# Altium schematic sheet RT_SUPER_B.SchDoc(RT_SUPER_B) (continued)

## Library data of the parts
BTN1 RESET1 = B3U-1100P: SWITCH TACTILE SPST-NO 0.05A 12V; Manufacturer: Omron Electronics Inc-EMC Div; Actuator Height off PCB, from Pins: 1.60mm; Actuator Orientation: Top Actuated; Actuator Type: Standard; CategorySort: 700; Contact Rating @ Voltage: 0.05A @ 12VDC; Illumination: Non-Illuminated; Ingress Protection: Dust Proof; Mechanical Life: 200,000 Cycles; Operating Force: 153gf; Outline: 3.00mm x 2.50mm; Switch Function: Off-Mom; Switch Travel: 0.15mm; Actuator Material: -; Category: Switches; Circuit: SPST-NO; Component Kind: Standard; ComponentLink1Description: http://www.components.omron.com/components/web/PDFLIB.nsf/0/2DD3893C7DD33E9E862572900079E9D6/$file/B3U_1110.pdf; ComponentLink1URL: http://www.components.omron.com/components/web/PDFLIB.nsf/0/2DD3893C7DD33E9E862572900079E9D6/$file/B3U_1110.pdf; Features: Grounding Pin; Illumination Type, Color: -; Illumination Voltage (Nominal): -; Library Name: Switches-N.SchLib; Manufacturer Part Number: B3U-1100P; Mounting Type: Surface Mount; Operating Temperature: -25°C ~ 70°C; Packaging: Cut Tape (CT); Pricing 1: 1=1.25, 10=1.201, 25=1.1332, 50=0.997, 100=0.9064, 250=0.86108, 500=0.83842, 1000=0.65714 (USD); RoHS: RoHS Compliant; Stock 1: 45170; Supplier 1: Digi-Key; Supplier Part Number 1: SW1021CT-ND; Termination Style: SMD (SMT) Tab; Value: B3U-1100P
C1 C5 C18 = GCM188R70J225KE22D: CAP CER 2.2UF 6.3V X7R 0603; Manufacturer: Murata Electronics; Database Table Name: C0603$; Substitution Notes: 2.2µF , 6.3V , ±10% , X7R , -55°C ~ 125°C , AEC-Q200 , 0603; Tolerance: ±10%; Category: Capacitors; Component Kind: Standard; ComponentLink1Description: Datasheet (Internal); ComponentLink1URL: https://search.murata.co.jp/Ceramy/image/img/A01X/G101/ENG/GCM188R70J225KE22-01.pdf; ComponentLink2Description: Datasheet (External); ComponentLink2URL: https://search.murata.co.jp/Ceramy/image/img/A01X/G101/ENG/GCM188R70J225KE22-01.pdf; Library Name: Capacitors - Murata - AEC-Q200.DbLib; Manufacturer Part Number: GCM188R70J225KE22D; Operating Temperature: -55°C ~ 125°C; Package: 0603; Ratings: AEC-Q200; Supplier 1: Digikey; Supplier Part Number 1: 490-5316-1-ND; Temperature Coefficient: X7R; Thickness (Max): 0.90MM; Value: 2.2µF; Voltage - Rated: 6.3V
C2 = GCJ188C70J475KE02D: CAP CER 4.7UF 6.3V X7S 0603; Manufacturer: Murata Electronics; Database Table Name: C0603$; Substitution Notes: 4.7µF , 6.3V , ±10% , X7S , -55°C ~ 125°C , AEC-Q200 , 0603; Tolerance: ±10%; Category: Capacitors; Component Kind: Standard; ComponentLink1Description: Datasheet (Internal); ComponentLink1URL: https://search.murata.co.jp/Ceramy/image/img/A01X/G101/ENG/GCJ188C70J475KE02-01.pdf; ComponentLink2Description: Datasheet (External); ComponentLink2URL: https://search.murata.co.jp/Ceramy/image/img/A01X/G101/ENG/GCJ188C70J475KE02-01.pdf; Library Name: Capacitors - Murata - AEC-Q200.DbLib; Manufacturer Part Number: GCJ188C70J475KE02D; Operating Temperature: -55°C ~ 125°C; Package: 0603; Ratings: AEC-Q200; Supplier 1: Digikey; Supplier Part Number 1: 490-16480-1-ND; Temperature Coefficient: X7S; Thickness (Max): 1.00MM; Value: 4.7µF; Voltage - Rated: 6.3V
C3 = GRT155R61E474KE01D: CAP CER 0.47UF 25V X5R 0402; Manufacturer: Murata Electronics; Database Table Name: C0402$; Substitution Notes: 0.47µF , 25V , ±10% , X5R , -55°C ~ 85°C , AEC-Q200 , 0402; Tolerance: ±10%; Category: Capacitors; Component Kind: Standard; ComponentLink1Description: Datasheet (Internal); ComponentLink1URL: https://search.murata.co.jp/Ceramy/image/img/A01X/partnumbering_e_02.pdf; ComponentLink2Description: Datasheet (External); ComponentLink2URL: https://search.murata.co.jp/Ceramy/image/img/A01X/partnumbering_e_02.pdf; Library Name: Capacitors - Murata - AEC-Q200.DbLib; Manufacturer Part Number: GRT155R61E474KE01D; Operating Temperature: -55°C ~ 85°C; Package: 0402; Ratings: AEC-Q200; Supplier 1: Digikey; Supplier Part Number 1: 490-12269-1-ND; Temperature Coefficient: X5R; Thickness (Max): 0.55MM; Value: 0.47µF; Voltage - Rated: 25V
C4 C8 C10 = GCM155C71A105KE38D: CAP CER 1UF 10V X7S 0402; Manufacturer: Murata Electronics; Database Table Name: C0402$; Substitution Notes: 1µF , 10V , ±10% , X7S , -55°C ~ 125°C , AEC-Q200 , 0402; Tolerance: ±10%; Category: Capacitors; Component Kind: Standard; ComponentLink1Description: Datasheet (Internal); ComponentLink1URL: https://www.murata.com/~/media/webrenewal/support/library/catalog/products/capacitor/mlcc/c03e.ashx?la=en-us; ComponentLink2Description: Datasheet (External); ComponentLink2URL: https://www.murata.com/~/media/webrenewal/support/library/catalog/products/capacitor/mlcc/c03e.ashx?la=en-us; Library Name: Capacitors - Murata - AEC-Q200.DbLib; Manufacturer Part Number: GCM155C71A105KE38D; Operating Temperature: -55°C ~ 125°C; Package: 0402; Ratings: AEC-Q200; Supplier 1: Digikey; Supplier Part Number 1: 490-13409-1-ND; Temperature Coefficient: X7S; Thickness (Max): 0.55MM; Value: 1µF; Voltage - Rated: 10V
C6 C12-C17 C20-C41 = (no symbol name): Cap Ceramic 0.1uF 6.3VDC X5R 10% SMD 0201 Paper T/R; Manufacturer: Yageo; Manufacturer Part Number: CC0201KRX5R5BB104; Capacitance: 100nF; Voltage Rating: 6.3V; Case Code (Imperial): 0201; Case Code (Metric): 0603; Case/Package: 0201; Category: Ceramic Capacitors; Component Kind: Standard; ComponentLink1Description: https://datasheet.ciiva.com/176/mlccorderingcode-176765.pdf?src-supplier=Digi-Key; ComponentLink1URL: https://datasheet.ciiva.com/176/mlccorderingcode-176765.pdf?src-supplier=Digi-Key; ComponentLink2Description: https://datasheet.ciiva.com/176/mlccorderingcode-176766.pdf?src-supplier=Digi-Key; ComponentLink2URL: https://datasheet.ciiva.com/176/mlccorderingcode-176766.pdf?src-supplier=Digi-Key; ComponentLink3Description: https://datasheet.ciiva.com/176/mlccorderingcode-176767.pdf?src-supplier=Digi-Key; ComponentLink3URL: https://datasheet.ciiva.com/176/mlccorderingcode-176767.pdf?src-supplier=Digi-Key; ComponentLink4Description: https://datasheet.ciiva.com/28450/1359354-28450200.pdf?src-supplier=Element14; ComponentLink4URL: https://datasheet.ciiva.com/28450/1359354-28450200.pdf?src-supplier=Element14; ComponentLink5Description: https://datasheet.ciiva.com/7656/1525783-7656597.pdf?src-supplier=Element14; ComponentLink5URL: https://datasheet.ciiva.com/7656/1525783-7656597.pdf?src-supplier=Element14; Depth: 0.3mm; Dielectric: X5R; Halogen Free: Halogen Free; Height: 0.3mm; Lead Free: Lead Free; Length: 0.6mm; Library Name: eCapacitors.SchLib; Max Operating Temperature: 85°C; Min Operating Temperature: -55°C; Mount: Surface Mount; Number of Pins: 2; Package Quantity: 1; Packaging: Tape and Reel; Pricing: 1=0.10000, 10=0.02400, 50=0.01340, 100=0.01090, 500=0.00776, 1000=0.00610, 2500=0.00555, 5000=0.00510 (USD); REACH SVHC: No SVHC; Resistor Type: Ceramic; RoHS: Yes; RoHS Compliant: Yes; Series: CC; Stock: 2999877; Supplier 1: Digi-Key; Supplier Part Number 1: 311-1408-1-ND; Termination: SMD/SMT; Thickness: 0.013inch; Tolerance: 10%; Value: 0.1uF 6v; Voltage Rating (DC): 6.3V; Width: 0.3mm
C7 C9 = GRT188R61A106ME13D: CAP CER 10UF 10V X5R 0603; Manufacturer: Murata Electronics; Database Table Name: C0603$; Substitution Notes: 10µF , 10V , ±20% , X5R , -55°C ~ 85°C , AEC-Q200 , 0603; Tolerance: ±20%; Category: Capacitors; Component Kind: Standard; ComponentLink1Description: Datasheet (Internal); ComponentLink1URL: https://search.murata.co.jp/Ceramy/image/img/A01X/G101/ENG/GRT188R61A106ME13-01.pdf; ComponentLink2Description: Datasheet (External); ComponentLink2URL: https://search.murata.co.jp/Ceramy/image/img/A01X/G101/ENG/GRT188R61A106ME13-01.pdf; Library Name: Capacitors - Murata - AEC-Q200.DbLib; Manufacturer Part Number: GRT188R61A106ME13D; Operating Temperature: -55°C ~ 85°C; Package: 0603; Ratings: AEC-Q200; Supplier 1: Digikey; Supplier Part Number 1: 490-12312-1-ND; Temperature Coefficient: X5R; Thickness (Max): 0.90MM; Value: 10µF; Voltage - Rated: 10V
C11 C19 = CGA2B2C0G1H180J050BA: CAP CER 18PF 50V C0G 0402; Manufacturer: TDK Corporation; Database Table Name: C0402$; Substitution Notes: 18pF , 50V , ±5% , C0G, NP0 , -55°C ~ 125°C , AEC-Q200 , 0402; Temperature Coefficient: C0G, NP0; Tolerance: ±5%; Category: Capacitors; Component Kind: Standard; ComponentLink1Description: Datasheet (Internal); ComponentLink1URL: datasheets\TDK\mlcc_automotive_general_en.pdf; ComponentLink2Description: Datasheet (External); ComponentLink2URL: https://product.tdk.com/info/en/catalog/datasheets/mlcc_automotive_general_en.pdf; Features: -; Library Name: Capacitors - TDK- AEC-Q200.DbLib; Manufacturer Part Number: CGA2B2C0G1H180J050BA; Operating Temperature: -55°C ~ 125°C; Package: 0402; Ratings: AEC-Q200; Supplier 1: Digikey; Supplier Part Number 1: 445-5587-1-ND; Thickness (Max): 0.55MM; Value: 18pF; Voltage - Rated: 50V
C42 = CGA4J3X7R1A475K125AB: CAP CER 4.7UF 10V X7R 0805; Manufacturer: TDK Corporation; Database Table Name: C0805$; Substitution Notes: 4.7µF , 10V , ±10% , X7R , -55°C ~ 125°C , AEC-Q200 , 0805; Tolerance: ±10%; Category: Capacitors; Component Kind: Standard; ComponentLink1Description: Datasheet (Internal); ComponentLink1URL: datasheets\TDK\mlcc_automotive_general_en.pdf; ComponentLink2Description: Datasheet (External); ComponentLink2URL: https://product.tdk.com/info/en/catalog/datasheets/mlcc_automotive_general_en.pdf; Features: -; Library Name: Capacitors - TDK- AEC-Q200.DbLib; Manufacturer Part Number: CGA4J3X7R1A475K125AB; Operating Temperature: -55°C ~ 125°C; Package: 0805; Ratings: AEC-Q200; Supplier 1: Digikey; Supplier Part Number 1: 445-12730-1-ND; Temperature Coefficient: X7R; Thickness (Max): 1.45MM; Value: 4.7µF; Voltage - Rated: 10V
C43 = CGA2B1X7R1C104K050BC: CAP CER 0.1UF 16V X7R 0402; Manufacturer: TDK Corporation; Database Table Name: C0402$; Substitution Notes: 0.1µF , 16V , ±10% , X7R , -55°C ~ 125°C , AEC-Q200 , 0402; Tolerance: ±10%; Category: Capacitors; Component Kind: Standard; ComponentLink1Description: Datasheet (Internal); ComponentLink1URL: datasheets\TDK\mlcc_automotive_general_en.pdf; ComponentLink2Description: Datasheet (External); ComponentLink2URL: https://product.tdk.com/info/en/catalog/datasheets/mlcc_automotive_general_en.pdf; Features: -; Library Name: Capacitors - TDK- AEC-Q200.DbLib; Manufacturer Part Number: CGA2B1X7R1C104K050BC; Operating Temperature: -55°C ~ 125°C; Package: 0402; Ratings: AEC-Q200; Supplier 1: Digikey; Supplier Part Number 1: 445-5613-1-ND; Temperature Coefficient: X7R; Thickness (Max): 0.55MM; Value: 0.1µF; Voltage - Rated: 16V
D1 D2 = BAT760-7: DIODE SCHOTTKY 30V 1A SOD323; Manufacturer: Diodes Inc; Capacitance @ Vr- F: 25pF @ 5V- 1MHz; CategorySort: 400; Current - Reverse Leakage @ Vr: 50µA @ 15V; Database Table Name: Schottky$; Diode Suffix: 1A_2C; Num Pins: 2; Speed: Fast Recovery =< 500ns- > 200mA (Io); Voltage - Forward (Vf) (Max) @ If: 550mV @ 1A; Category: Diodes; Component Kind: Standard; ComponentLink1Description: Internal Datasheet; ComponentLink1URL: http://www.diodes.com/datasheets/ds30498.pdf; ComponentLink2Description: External Datasheet; ComponentLink2URL: http://www.diodes.com/datasheets/ds30498.pdf; Current - Average Rectified (Io): 1A; Diode Type: Schottky; Library Name: Diodes.DbLib; Manufacturer Part Number: BAT760-7; Mounting Type: Surface Mount; Package: SC-76- SOD-323; Packaging: Cut Tape (CT); Reverse Recovery Time (trr): -; SortValue: BAT760-7; Supplier 1: Digi-Key; Supplier Device Package: SOD-323; Supplier Part Number 1: BAT760DICT-ND; Value: BAT760-7; Voltage - DC Reverse (Vr) (Max): 30V
J1 = S2B-PH-SM4-TB(LF)(SN): CONN HEADER PH SIDE 2POS 2MM SMD; Manufacturer: JST Sales America Inc; Connector Type: Header, Shrouded; Number of Positions: 2; Number of Positions Loaded: All; Pitch: 0.079" (2.00mm); Category: Connectors, Interconnects; Color: Natural; Component Kind: Standard; ComponentLink1Description: http://www.jst-mfg.com/product/pdf/eng/ePH.pdf; ComponentLink1URL: http://www.jst-mfg.com/product/pdf/eng/ePH.pdf; Contact Finish: Tin; Contact Finish Thickness: -; Contact Mating Length: -; Contact Type: Male Pin; Fastening Type: -; Features: Solder Retention; Library Name: eConnector_JST_PH.SchLib; Manufacturer Part Number: S2B-PH-SM4-TB(LF)(SN); Mounting Type: Surface Mount, Right Angle; Number of Rows: 1; Packaging: Cut Tape (CT); Pricing 1: 1=0.5, 10=0.457, 100=0.3809, 500=0.3047 (USD); RoHS: RoHS Compliant; Row Spacing: -; Stock 1: 17700; Supplier 1: Digi-Key; Supplier Part Number 1: 455-1749-1-ND; Termination: Solder; Value: S2B-PH-SM4-TB(LF)(SN)
J2 = 10103594-0001LF: CONN RCPT STD MICRO USB TYPE B; Manufacturer: FCI; Connector Type: USB - micro B; Gender: Receptacle; Micro USB B: Value; Notes: Similar to; Number of Contacts: 5; Specifications: USB 2.0; Category: Connectors, Interconnects; Component Kind: Standard; ComponentLink1Description: http://portal.fciconnect.com/res/en/pdffiles/doc_search/10103594.pdf; ComponentLink1URL: http://portal.fciconnect.com/res/en/pdffiles/doc_search/10103594.pdf; Features: Shielded, Solder Retention; Ingress Protection: -; Library Name: eConnector_USB.SchLib; Manufacturer Part Number: 10103594-0001LF; Mounting Type: Surface Mount, Right Angle, Horizontal; Packaging: Cut Tape (CT); Pricing 1: 1=1.1, 10=0.768, 100=0.517, 250=0.43912, 500=0.41716 (USD); RoHS: RoHS Compliant; Stock 1: 23288; Supplier 1: Digi-Key; Supplier Part Number 1: 609-4050-1-ND; Value: 10103594-0001LF
J3 = CORTEX-DEBUG: SAMTEC - FTSH-105-01-F-DV - .050 X .050; Category: Connectors; Component Kind: Standard; Library Name: eConnector.SchLib; Manufacturer: SAMTEC; Manufacturer Part Number: FTSH-105-01-F-DV; Pricing 1: 1=0.974, 25000=0.835, 50000=0.774 (USD); Stock 1: 0; Supplier 1: Newark; Supplier Part Number 1: 01R3399; Value: FTSH-105-01-F-DV
L1 = (no symbol name): FIXED IND 4.7UH 520MA 650 MOHM; Manufacturer: Taiyo Yuden; Core Material: Ferrite; DC Resistance (DCR): 650mR; Inductance Tolerance: 20%; Saturation Current: 360mA; Self Resonant Frequency: 45MHz; Shielding: Unshielded; Test Frequency: 7.96MHz; Case Code (Imperial): 0805; Case Code (Metric): 2012; Case/Package: 0805; Component Kind: Standard; ComponentLink1Description: https://datasheet.ciiva.com/15981/214cbc2012t4r7m-15981637.pdf?src-supplier=Onlinecomponents.com; ComponentLink1URL: https://datasheet.ciiva.com/15981/214cbc2012t4r7m-15981637.pdf?src-supplier=Onlinecomponents.com; ComponentLink2Description: https://datasheet.ciiva.com/1040/cbc2012t4r7m-ss-1040456.pdf?src-supplier=Digi-Key; ComponentLink2URL: https://datasheet.ciiva.com/1040/cbc2012t4r7m-ss-1040456.pdf?src-supplier=Digi-Key; ComponentLink3Description: https://datasheet.ciiva.com/8505/cbc2012t4r7m-ss-8505656.pdf?src-supplier=Avnet; ComponentLink3URL: https://datasheet.ciiva.com/8505/cbc2012t4r7m-ss-8505656.pdf?src-supplier=Avnet; ComponentLink4Description: https://datasheet.ciiva.com/8001/wound02-e-8001849.pdf?src-supplier=Element14; ComponentLink4URL: https://datasheet.ciiva.com/8001/wound02-e-8001849.pdf?src-supplier=Element14; Composition: Wirewound; Current Rating: 520mA; Halogen Free: Halogen Free; Height: 1.25mm; Height - Seated (Max): 0.057inch; Inductance: 4.7uH; Lead Free: Exempt; Length: 0.079inch; Library Name: eInductor.SchLib; Manufacturer Part Number: CBC2012T4R7M; Max DC Current: 520mA; Max Operating Temperature: 105°C; Min Operating Temperature: -40°C; Mount: Surface Mount; Number of Pins: 2; Package Quantity: 3000; Packaging: Tape and Reel; Pricing 1: 1=0.24000, 10=0.21600, 100=0.14860, 500=0.12702, 1000=0.09729 (USD); REACH SVHC: No SVHC; RoHS: Yes; Stock 1: 7720; Supplier 1: Digi-Key; Supplier Part Number 1: 587-1602-1-ND; Termination: SMD/SMT; Tolerance: 20%; Value: CBC2012T4R7M; Width: 0.049inch
L2 L3 = NRH3012T2R2MN: Inductor 2.2µH 1.5A; Manufacturer: Taiyo Yuden; CategorySort: 300; Database Table Name: Taiyo Yuden$; DCR Max (Ohms): 90 mOhm Max; Inductance (uH): 2.2; IRMS: 1.37; Isat (A): 1.5; Shielding: Sheilded; SRF typ (MHz): 78MHz; Tolerance: ±20%; Category: Inductors; Component Kind: Standard; ComponentLink1Description: External Datasheet; ComponentLink1URL: https://www.yuden.co.jp/productdata/catalog/en/wound04_e.pdf; Height (mm): 1.2MM; Library Name: Inductors.DbLib; Manufacturer Part Number: NRH3012T2R2MN; Package: NRH3012; Part Number: NRH3012T2R2MN; Rating: -; Sort Value: 2.2_1.5; Sort Value Package: 2.2_1.5_NRH3012; Supplier 1: Digikey; Supplier Part Number 1: 587-2589-1-ND; Supplier Unit Price 1: 0.28; Value: 2.2µH 1.5A
Q1 = ZXMN3A01FTA: MOSFET N-CH 30V 1.8A SOT23-3; Manufacturer: Diodes/Zetex; FET Feature: Logic Level Gate; FET Type: MOSFET N-Channel, Metal Oxide; Gate Charge (Qg) @ Vgs: 3.9nC @ 10V; Input Capacitance (Ciss) @ Vds: 190pF @ 25V; Power - Max: 625mW; Rds On (Max) @ Id, Vgs: 120 mOhm @ 2.5A, 10V; Vgs(th) (Max) @ Id: 1V @ 250µA; Category: Discrete Semiconductor Products; Component Kind: Standard; ComponentLink1Description: http://www.diodes.com/datasheets/ZXMN3A01F.pdf; ComponentLink1URL: http://www.diodes.com/datasheets/ZXMN3A01F.pdf; Current - Continuous Drain (Id) @ 25° C: 1.8A; Drain to Source Voltage (Vdss): 30V; Library Name: eTransistor.SchLib; Manufacturer Part Number: ZXMN3A01FTA; Mounting Type: Surface Mount; Package / Case: SOT-23-3, TO-236-3, Micro3™, SSD3, SST3; Packaging: Cut Tape (CT); Pricing 1: 1=0.55, 10=0.44, 100=0.33, 250=0.285, 500=0.245, 1000=0.19 (USD); RoHS: RoHS Compliant; Stock 1: 33955; Supplier 1: Digi-Key; Supplier Part Number 1: ZXMN3A01FCT-ND; Value: ZXMN3A01FTA
R1 = ERJ-2RKF1002X: RES 10K OHM 1/10W 1% 0402 SMD; Manufacturer: Panasonic Electronic Components; Database Table Name: 1%$; Limiting Element Voltage: 50; Maximum Overload Voltage: 100; Maximum Working Voltage: 31.6V; Package Power: 0402  (0.1W); Power (Watts): 0.1W- 1/10W; Substitution Notes: 10k , ±1% , 0402 , AEC-Q200; Temperature Coefficient: ±100ppm/°C; Category: Resistors; Component Kind: Standard; ComponentLink1Description: Internal Datasheet; ComponentLink1URL: datasheets\Panasonic Electronic Components\AOA0000CE2.pdf; ComponentLink2Description: External Datasheet; ComponentLink2URL: http://industrial.panasonic.com/www-data/pdf/AOA0000/AOA0000CE2.pdf; Composition: Thick Film; Features: -; Height: 0.40MM; Library Name: Resistors - Panasonic - AEC-Q200.DbLib; Manufacturer Part Number: ERJ-2RKF1002X; Number of Terminations: 2; Package: 0402 (1005 Metric); Packaging: Cut Tape (CT); Ratings: AEC-Q200; Resistance (Ohms): 10k; Size / Dimension: 0.039" L x 0.020" W (1.00mm x 0.50mm); Sort Value: 10000; Sort Value Package: 402_10000; Supplier 1: Digi-Key; Supplier Package: 0402; Supplier Part Number 1: P10.0KLCT-ND; Tolerance: ±1%; Value: 10k
R2 R5 = ERJ-2RKF2002X: RES 20K OHM 1/10W 1% 0402 SMD; Manufacturer: Panasonic Electronic Components; Database Table Name: 1%$; Limiting Element Voltage: 50; Maximum Overload Voltage: 100; Maximum Working Voltage: 44.7V; Package Power: 0402  (0.1W); Power (Watts): 0.1W- 1/10W; Substitution Notes: 20k , ±1% , 0402 , AEC-Q200; Temperature Coefficient: ±100ppm/°C; Category: Resistors; Component Kind: Standard; ComponentLink1Description: Internal Datasheet; ComponentLink1URL: datasheets\Panasonic Electronic Components\AOA0000CE2.pdf; ComponentLink2Description: External Datasheet; ComponentLink2URL: http://industrial.panasonic.com/www-data/pdf/AOA0000/AOA0000CE2.pdf; Composition: Thick Film; Features: -; Height: 0.40MM; Library Name: Resistors - Panasonic - AEC-Q200.DbLib; Manufacturer Part Number: ERJ-2RKF2002X; Number of Terminations: 2; Package: 0402 (1005 Metric); Packaging: Cut Tape (CT); Ratings: AEC-Q200; Resistance (Ohms): 20k; Size / Dimension: 0.039" L x 0.020" W (1.00mm x 0.50mm); Sort Value: 20000; Sort Value Package: 402_20000; Supplier 1: Digi-Key; Supplier Package: 0402; Supplier Part Number 1: P20.0KLCT-ND; Tolerance: ±1%; Value: 20k
R3 R4 = ERJ-2RKF2201X: RES 2.2K OHM 1/10W 1% 0402 SMD; Manufacturer: Panasonic Electronic Components; Database Table Name: 1%$; Limiting Element Voltage: 50; Maximum Overload Voltage: 100; Maximum Working Voltage: 14.8V; Package Power: 0402  (0.1W); Power (Watts): 0.1W- 1/10W; Substitution Notes: 2.2k , ±1% , 0402 , AEC-Q200; Temperature Coefficient: ±100ppm/°C; Category: Resistors; Component Kind: Standard; ComponentLink1Description: Internal Datasheet; ComponentLink1URL: datasheets\Panasonic Electronic Components\AOA0000CE2.pdf; ComponentLink2Description: External Datasheet; ComponentLink2URL: http://industrial.panasonic.com/www-data/pdf/AOA0000/AOA0000CE2.pdf; Composition: Thick Film; Features: -; Height: 0.40MM; Library Name: Resistors - Panasonic - AEC-Q200.DbLib; Manufacturer Part Number: ERJ-2RKF2201X; Number of Terminations: 2; Package: 0402 (1005 Metric); Packaging: Cut Tape (CT); Ratings: AEC-Q200; Resistance (Ohms): 2.2k; Size / Dimension: 0.039" L x 0.020" W (1.00mm x 0.50mm); Sort Value: 2200; Sort Value Package: 402_2200; Supplier 1: Digi-Key; Supplier Package: 0402; Supplier Part Number 1: P2.20KLCT-ND; Tolerance: ±1%; Value: 2.2k
R6 R7 R10 = ERJ-2GE0R00X: RES 0.0 OHM 1/10W JUMP 0402 SMD; Manufacturer: Panasonic Electronic Components; Database Table Name: 5%$; Limiting Element Voltage: 50; Maximum Overload Voltage: 100; Maximum Working Voltage: 0.0V; Package Power: 0402  (0.1W); Power (Watts): 0.1W- 1/10W; Substitution Notes: 0 , Jumper , 0402 , AEC-Q200; Tolerance: Jumper; Category: Resistors; Component Kind: Standard; ComponentLink1Description: Internal Datasheet; ComponentLink1URL: datasheets\Panasonic Electronic Components\AOA0000CE1.pdf; ComponentLink2Description: External Datasheet; ComponentLink2URL: http://industrial.panasonic.com/www-data/pdf/AOA0000/AOA0000CE1.pdf; Composition: Thick Film; Features: -; Height: 0.40MM; Library Name: Resistors - Panasonic - AEC-Q200.DbLib; Manufacturer Part Number: ERJ-2GE0R00X; Number of Terminations: 2; Package: 0402 (1005 Metric); Ratings: AEC-Q200; Resistance (Ohms): 0; Size / Dimension: 0.039" L x 0.020" W (1.00mm x 0.50mm); Sort Value: 0; Sort Value Package: 402_0; Supplier 1: Digi-Key; Supplier Package: 0402; Supplier Part Number 1: P0.0JCT-ND; Temperature Coefficient: -; Value: 0
R8 R12-R14 = ERJ-2RKF1003X: RES 100K OHM 1/10W 1% 0402 SMD; Manufacturer: Panasonic Electronic Components; Database Table Name: 1%$; Limiting Element Voltage: 50; Maximum Overload Voltage: 100; Maximum Working Voltage: 50.0V; Package Power: 0402  (0.1W); Power (Watts): 0.1W- 1/10W; Substitution Notes: 100k , ±1% , 0402 , AEC-Q200; Temperature Coefficient: ±100ppm/°C; Category: Resistors; Component Kind: Standard; ComponentLink1Description: Internal Datasheet; ComponentLink1URL: datasheets\Panasonic Electronic Components\AOA0000CE2.pdf; ComponentLink2Description: External Datasheet; ComponentLink2URL: http://industrial.panasonic.com/www-data/pdf/AOA0000/AOA0000CE2.pdf; Composition: Thick Film; Features: -; Height: 0.40MM; Library Name: Resistors - Panasonic - AEC-Q200.DbLib; Manufacturer Part Number: ERJ-2RKF1003X; Number of Terminations: 2; Package: 0402 (1005 Metric); Packaging: Cut Tape (CT); Ratings: AEC-Q200; Resistance (Ohms): 100k; Size / Dimension: 0.039" L x 0.020" W (1.00mm x 0.50mm); Sort Value: 100000; Sort Value Package: 402_100000; Supplier 1: Digi-Key; Supplier Package: 0402; Supplier Part Number 1: P100KLCT-ND; Tolerance: ±1%; Value: 100k
R9 R11 R15 = ERJ-2RKF33R0X: RES 33 OHM 1/10W 1% 0402 SMD; Manufacturer: Panasonic Electronic Components; Database Table Name: 1%$; Limiting Element Voltage: 50; Maximum Overload Voltage: 100; Maximum Working Voltage: 1.8V; Package Power: 0402  (0.1W); Power (Watts): 0.1W- 1/10W; Substitution Notes: 33 , ±1% , 0402 , AEC-Q200; Temperature Coefficient: ±100ppm/°C; Category: Resistors; Component Kind: Standard; ComponentLink1Description: Internal Datasheet; ComponentLink1URL: datasheets\Panasonic Electronic Components\AOA0000CE2.pdf; ComponentLink2Description: External Datasheet; ComponentLink2URL: http://industrial.panasonic.com/www-data/pdf/AOA0000/AOA0000CE2.pdf; Composition: Thick Film; Features: -; Height: 0.40MM; Library Name: Resistors - Panasonic - AEC-Q200.DbLib; Manufacturer Part Number: ERJ-2RKF33R0X; Number of Terminations: 2; Package: 0402 (1005 Metric); Packaging: Cut Tape (CT); Ratings: AEC-Q200; Resistance (Ohms): 33; Size / Dimension: 0.039" L x 0.020" W (1.00mm x 0.50mm); Sort Value: 33; Sort Value Package: 402_33; Supplier 1: Digi-Key; Supplier Package: 0402; Supplier Part Number 1: P33.0LCT-ND; Tolerance: ±1%; Value: 33
R16 = ERJ-2RKF1001X: RES 1K OHM 1/10W 1% 0402 SMD; Manufacturer: Panasonic Electronic Components; Database Table Name: 1%$; Limiting Element Voltage: 50; Maximum Overload Voltage: 100; Maximum Working Voltage: 10.0V; Package Power: 0402  (0.1W); Power (Watts): 0.1W- 1/10W; Substitution Notes: 1k , ±1% , 0402 , AEC-Q200; Temperature Coefficient: ±100ppm/°C; Category: Resistors; Component Kind: Standard; ComponentLink1Description: Internal Datasheet; ComponentLink1URL: datasheets\Panasonic Electronic Components\AOA0000CE2.pdf; ComponentLink2Description: External Datasheet; ComponentLink2URL: http://industrial.panasonic.com/www-data/pdf/AOA0000/AOA0000CE2.pdf; Composition: Thick Film; Features: -; Height: 0.40MM; Library Name: Resistors - Panasonic - AEC-Q200.DbLib; Manufacturer Part Number: ERJ-2RKF1001X; Number of Terminations: 2; Package: 0402 (1005 Metric); Packaging: Cut Tape (CT); Ratings: AEC-Q200; Resistance (Ohms): 1k; Size / Dimension: 0.039" L x 0.020" W (1.00mm x 0.50mm); Sort Value: 1000; Sort Value Package: 402_1000; Supplier 1: Digi-Key; Supplier Package: 0402; Supplier Part Number 1: P1.00KLCT-ND; Tolerance: ±1%; Value: 1k
SW1-SW3 = CHS-01TA: NIDEC COPAL ELECTRONICS - CHS-01TA - DIP SWITCH, 1POS, SPST, SLIDE, SMD; Actuator Type: Slide (Standard); Body Material: Polyphenylene; Current Rating: 100mA; Electrical Life: 2000Cycles; Max Voltage Rating (DC): 24V; Number of Positions: 1; Pitch: 0.05inch; Voltage Rating (DC): 6V; Washable: Yes; Category: DIP Switches; Component Kind: Standard; ComponentLink1Description: https://datasheet.ciiva.com/2863/chs-2863448.pdf?src-supplier=Digi-Key; ComponentLink1URL: https://datasheet.ciiva.com/2863/chs-2863448.pdf?src-supplier=Digi-Key; ComponentLink2Description: https://datasheet.ciiva.com/2863/chs-2863449.pdf?src-supplier=Digi-Key; ComponentLink2URL: https://datasheet.ciiva.com/2863/chs-2863449.pdf?src-supplier=Digi-Key; ComponentLink3Description: https://datasheet.ciiva.com/2863/chs-2863450.pdf?src-supplier=Digi-Key; ComponentLink3URL: https://datasheet.ciiva.com/2863/chs-2863450.pdf?src-supplier=Digi-Key; Contact Material: Copper Alloy; Contact Plating: Gold; Lead Free: Lead Free; Length: 0.098inch; Library Name: eElectroMechanical.SchLib; Manufacturer: Nidec Copal; Manufacturer Part Number: CHS-01TA; Max Operating Temperature: 85°C; Min Operating Temperature: -40°C; Mount: Surface Mount; Orientation: Vertical; Packaging: Tape and Reel; Pricing 1: 1000=0.57079, 2000=0.57024, 4000=0.56944, 6000=0.46309, 8000=0.46247 (USD); RoHS: Yes; Stock 1: 19000; Supplier 1: Avnet; Supplier Part Number 1: CHS-01TA; Termination: J-Hook; Throw Configuration: SPST; Value: CHS-01TA
U1 = MIMXRT685SFVKB: SOC i.MX ARM Cortex M33 176-Pin VFBGA Tray; Manufacturer: NXP Semiconductors; Availability: Warning; Check_prices: https://www.snapeda.com/parts/MIMXRT633SFVKB/Nexperia/view-part/5163781/?ref=eda; Component Kind: Standard; Library Name: eIC.SchLib; Manufacturer Part Number: MIMXRT685SFVKB; Package: None; Price: None; Purchase-URL: https://pricing.snapeda.com/search/part/MIMXRT633SFVKB/?ref=eda; SnapEDA_Link: https://www.snapeda.com/parts/MIMXRT633SFVKB/Nexperia/view-part/5163781/?ref=snap; Value: MIMXRT685SFVKB
U2 = PCA9420BSAZ: Power Management IC for Low-Power Microcontroller Applications 24-Pin HVQFN; Manufacturer: NXP Semiconductors; Check_prices: https://www.snapeda.com/parts/PCA9420BSAZ/NXP%20Semiconductors/view-part/5003095/?ref=eda; Component Kind: Standard; Library Name: eIC.SchLib; Manufacturer Part Number: PCA9420BSAZ; Pricing: 1=3.09000, 10=2.78000, 50=2.63000, 100=2.28000, 1000=2.23000, 10000=2.20000 (USD); RoHS: Yes; RoHS Compliant: Yes; SnapEDA_Link: https://www.snapeda.com/parts/PCA9420BSAZ/NXP%20Semiconductors/view-part/5003095/?ref=snap; Stock: 953; Supplier 1: Mouser; Supplier Part Number 1: 771-PCA9420BSAZ
U3 = (no symbol name): IC BUFF NON-INVERT 2.7 V SOT23-5; Manufacturer: Texas Instruments; High Level Output Current: 9mA; Logic Function: Buffer,Inverting; Max Supply Voltage: 2.7V; Min Supply Voltage: 800mV; Number of Bits: 1; Polarity: Non-Inverting; Propagation Delay: 1.7ns; Quiescent Current: 10uA; Turn-On Delay Time: 3.3ns; Case/Package: SOT-23-5; Category: Buffers, Drivers and Transceivers; Component Kind: Standard; ComponentLink1Description: https://datasheet.ciiva.com/1833/sn74auc1g07-1833760.pdf?src-supplier=Digi-Key; ComponentLink1URL: https://datasheet.ciiva.com/1833/sn74auc1g07-1833760.pdf?src-supplier=Digi-Key; ComponentLink2Description: https://datasheet.ciiva.com/14302/sces373q-14302179.pdf?src-supplier=Avnet; ComponentLink2URL: https://datasheet.ciiva.com/14302/sces373q-14302179.pdf?src-supplier=Avnet; ComponentLink3Description: https://datasheet.ciiva.com/15094/getdatasheetpartid-69632-15094449.pdf?src-supplier=Verical; ComponentLink3URL: https://datasheet.ciiva.com/15094/getdatasheetpartid-69632-15094449.pdf?src-supplier=Verical; ComponentLink4Description: https://datasheet.ciiva.com/1833/sn74auc1g07-1833761.pdf?src-supplier=Digi-Key; ComponentLink4URL: https://datasheet.ciiva.com/1833/sn74auc1g07-1833761.pdf?src-supplier=Digi-Key; ComponentLink5Description: https://datasheet.ciiva.com/1833/sn74auc1g07-1833762.pdf?src-supplier=Digi-Key; ComponentLink5URL: https://datasheet.ciiva.com/1833/sn74auc1g07-1833762.pdf?src-supplier=Digi-Key; ComponentLink6Description: https://datasheet.ciiva.com/14302/sces373q-14302175.pdf?src-supplier=Avnet; ComponentLink6URL: https://datasheet.ciiva.com/14302/sces373q-14302175.pdf?src-supplier=Avnet; Lead Free: Lead Free; Library Name: eIC.SchLib; Low Level Output Current: 9mA; Manufacturer Part Number: SN74AUC1G07DBVR; Max Operating Temperature: 85°C; Min Operating Temperature: -40°C; Mount: Surface Mount; Number of Bits per Element: 1; Number of Channels: 1; Number of Elements: 1; Number of Gates: 1; Number of Input Lines: 1; Number of Inputs: 1; Number of Output Lines: 1; Number of Outputs: 1; Number of Pins: 5; Package Quantity: 3000; Packaging: Tape and Reel; Pricing: 1=0.41000, 10=0.32900, 25=0.30080, 100=0.22390, 250=0.20288, 500=0.16790, 1000=0.12593 (USD); Radiation Hardening: No; REACH SVHC: Unknown; RoHS: Yes; RoHS Compliant: Yes; Series: 74AUC; Stock: 6487; Supplier 1: Digi-Key; Supplier Part Number 1: 296-12463-1-ND; Technology: CMOS; Value: SN74AUC1G07DBVR
U4 = PRTR5V0U2X,215: TVS DIODE 5.5VWM SOT143B; Manufacturer: NXP Semiconductors; Bidirectional Channels: 2; Capacitance @ Frequency: 1pF @ 1MHz; Power Line Protection: Yes; Voltage - Breakdown (Min): 6V; Voltage - Reverse Standoff (Typ): 5.5V (Max); Applications: General Purpose; Category: Circuit Protection; Component Kind: Standard; ComponentLink1Description: http://www.nxp.com/documents/data_sheet/PRTR5V0U2X.pdf; ComponentLink1URL: http://www.nxp.com/documents/data_sheet/PRTR5V0U2X.pdf; Current - Peak Pulse (10/1000µs): -; Library Name: eDiode.SchLib; Manufacturer Part Number: PRTR5V0U2X,215; Mounting Type: Surface Mount; Operating Temperature: -40°C ~ 85°C (TA); Package / Case: TO-253-4, TO-253AA; Packaging: Cut Tape (CT); Power - Peak Pulse: -; Pricing: 1=0.57, 10=0.477, 25=0.418, 100=0.3578, 250=0.31036, 500=0.26286, 1000=0.20265 (USD); RoHS: RoHS Compliant; Stock: 11734; Supplier 1: Digi-Key; Supplier Device Package: SOT-143B; Supplier Part Number 1: 568-4140-1-ND; Type: Steering (Rail to Rail); Unidirectional Channels: -; Value: PRTR5V0U2X,215; Voltage - Clamping (Max) @ Ipp: -
U5 = ABM3-24.000MHZ-B2-T: CRYSTAL 24.000MHZ 18PF SMD; Manufacturer: Abracon Corporation; Frequency Stability: ±50ppm; Frequency Tolerance: ±20ppm; Operating Mode: Fundamental; Category: Crystals and Oscillators; Component Kind: Standard; ComponentLink1Description: http://www.abracon.com/Resonators/abm3.pdf; ComponentLink1URL: http://www.abracon.com/Resonators/abm3.pdf; Frequency: 24MHz; Height: 0.051" (1.30mm); Library Name: eCrystal.SchLib; Manufacturer Part Number: ABM3-24.000MHZ-B2-T; Mounting Type: Surface Mount; Operating Temperature: -20°C ~ 70°C; Package / Case: 2-SMD; Packaging: Cut Tape (CT); Pricing 1: 1=1.43, 10=1.235, 50=1.083, 100=0.855, 500=0.722 (USD); RoHS: RoHS Compliant; Size / Dimension: 0.197" L x 0.126" W (5.00mm x 3.20mm); Stock 1: 7349; Supplier 1: Digi-Key; Supplier Part Number 1: 535-9106-1-ND; Type: MHz Crystal; Value: 24MHz
U6 = W25Q128JVSIM: NOR Flash Serial (SPI, Dual SPI, Quad SPI) 3V/3.3V 128M-bit 16M x 8 6ns 8-Pin SOIC Tube; Manufacturer: Winbond; Manufacturer Part Number: W25Q64JVSSIQ; Address Bus Width: 24b; Density: 64MB; Frequency: 104MHz; Interface: SPI,Serial; Max Supply Voltage: 3.6V; Memory Size: 128Mb; Memory Type: NOR,FLASH; Min Supply Voltage: 2.7V; Operating Supply Voltage: 3V; Page Size: 256B; Access Time: 6ns; Case/Package: SOIC; Category: Flash; Component Kind: Standard; ComponentLink1Description: http://www.winbond.com/resource-files/w25q64jv%20spi%20revg%2012142016.pdf; ComponentLink1URL: http://www.winbond.com/resource-files/w25q64jv%20spi%20revg%2012142016.pdf; ComponentLink2Description: https://www.winbond.com/resource-files/w25q64jv%20spi%20%20%20revc%2006032016%20kms.pdf; ComponentLink2URL: https://www.winbond.com/resource-files/w25q64jv%20spi%20%20%20revc%2006032016%20kms.pdf; ComponentLink3Description: http://www.winbond.com/resource-files/w25q128jv_dtr%20revc%2003272018%20plus.pdf?src-supplier=Digi-Key; ComponentLink3URL: http://www.winbond.com/resource-files/w25q128jv_dtr%20revc%2003272018%20plus.pdf?src-supplier=Digi-Key; Library Name: eIC.SchLib; Max Operating Temperature: 85°C; Min Operating Temperature: -40°C; Mount: Surface Mount; Packaging: Tray; Pricing 1: 1=1.88000, 10=1.71400, 25=1.66760, 50=1.65880, 100=1.48280, 250=1.47852, 500=1.45618, 1000=1.39437, 5000=1.29820 (USD); RoHS: Yes; RoHS Compliant: Yes; Stock 1: 27539; Supplier 1: Digi-Key; Supplier Part Number 1: W25Q128JVSIM-ND; Value: W25Q64JVSIM
U7 = (no symbol name): 1.54" 240x240 IPS Display; Manufacturer: Buy Display; Component Kind: Standard; Library Name: eDisplay.SchLib; Manufacturer Part Number: ER-TFT1.54-1; Value: ER-TFT1.54-1
F1-F6 P1-P16 P20-P48 TP1-TP7 = (no symbol name)
  F1-F6: Description: PCB Fiducial; Library Name: eFiducial.SchLib; Value: PCB Fiducial
  P1-P16 P20-P48: Library Name: eConnector.SchLib
  TP1-TP7: Library Name: eTestPoint.SchLib; Value: SMT_TEST
